# Altium schematic sheet Single Channel Isolated Gate Driver Test Board.SchDot
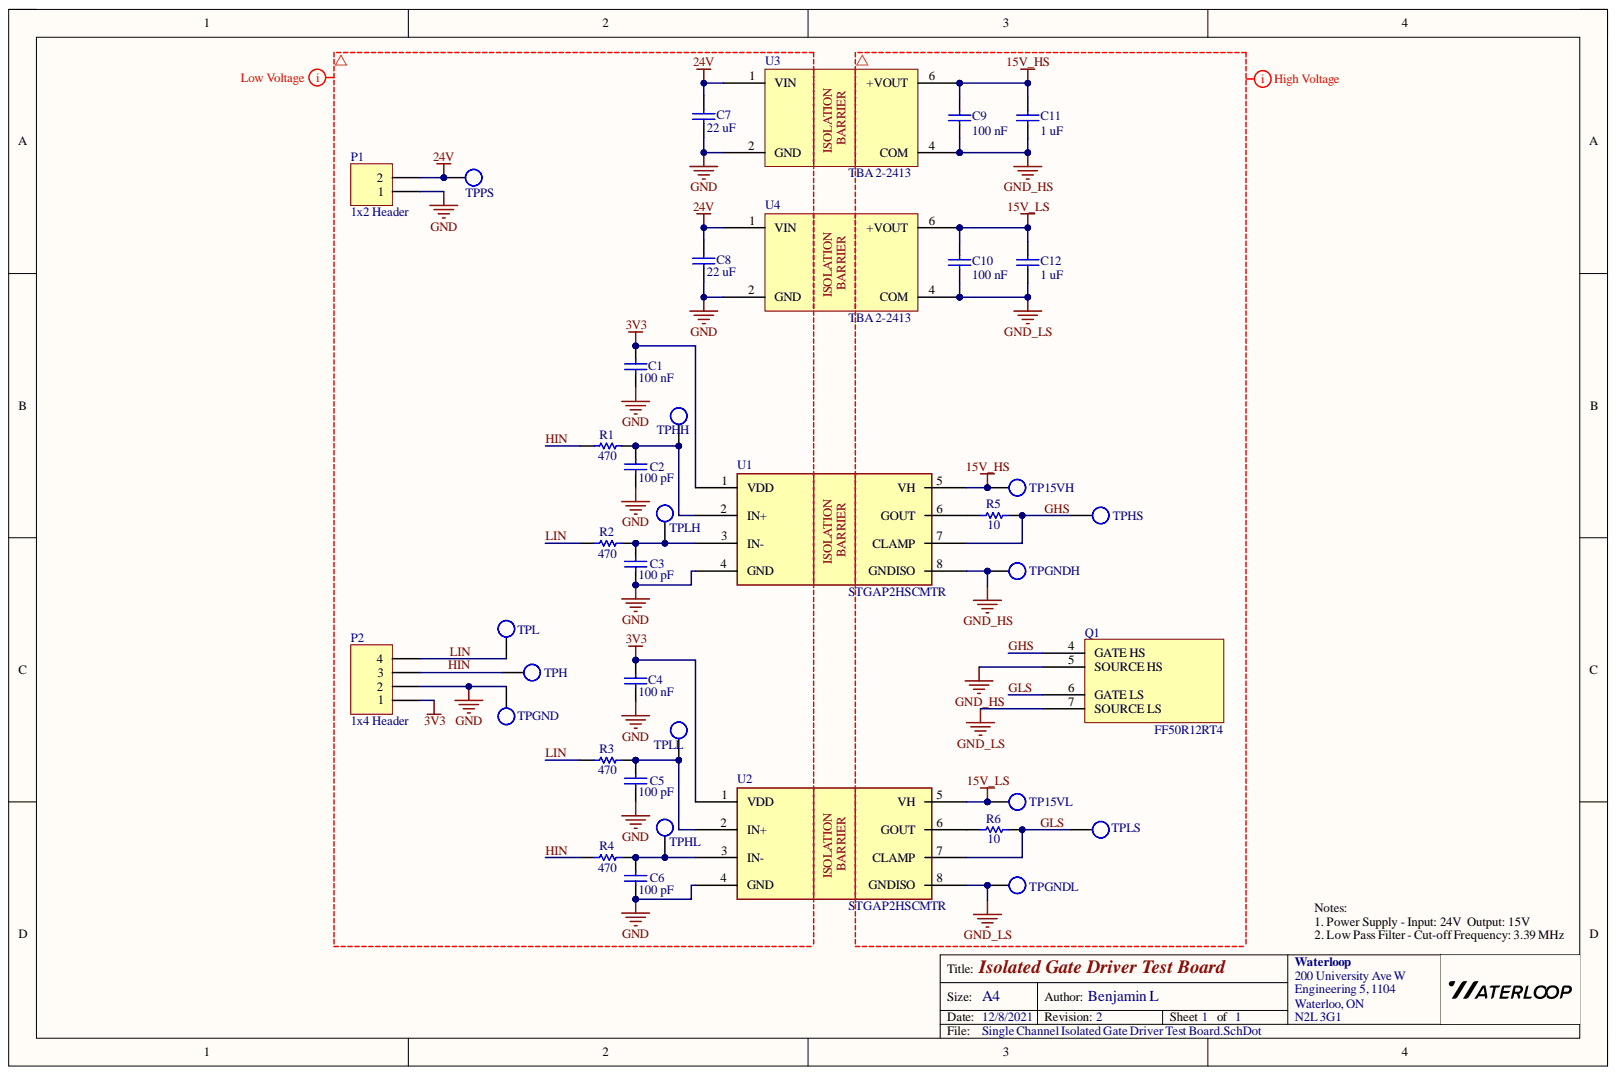
[diagram p0: Single Channel Isolated Gate Driver Test Board.SchDot]

## Parts
C1  value 100 nF  [0805 - Capacitor]  (hidden comment: Capacitor - Nonpolarized)
C2  value 100 pF  [0805 - Capacitor]  (hidden comment: Capacitor - Nonpolarized)
C3  value 100 pF  [0805 - Capacitor]  (hidden comment: Capacitor - Nonpolarized)
C4  value 100 nF  [0805 - Capacitor]  (hidden comment: Capacitor - Nonpolarized)
C5  value 100 pF  [0805 - Capacitor]  (hidden comment: Capacitor - Nonpolarized)
C6  value 100 pF  [0805 - Capacitor]  (hidden comment: Capacitor - Nonpolarized)
C7  value 22 uF  [1206 - Capacitor]  (hidden comment: Capacitor - Nonpolarized)
C8  value 22 uF  [1206 - Capacitor]  (hidden comment: Capacitor - Nonpolarized)
C9  value 100 nF  [0805 - Capacitor]  (hidden comment: Capacitor - Nonpolarized)
C10  value 100 nF  [0805 - Capacitor]  (hidden comment: Capacitor - Nonpolarized)
C11  value 1 uF  [0805 - Capacitor]  (hidden comment: Capacitor - Nonpolarized)
C12  value 1 uF  [0805 - Capacitor]  (hidden comment: Capacitor - Nonpolarized)
P1  1x2 Header  [B2B-XH]
P2  1x4 Header  [B4B-XH]
Q1  FF50R12RT4  [FF50R12RT4]
R1  value 470  [0603 - Resistor]  (hidden comment: Resistor)
R2  value 470  [0603 - Resistor]  (hidden comment: Resistor)
R3  value 470  [0603 - Resistor]  (hidden comment: Resistor)
R4  value 470  [0603 - Resistor]  (hidden comment: Resistor)
R5  value 10  [1206 - Resistor]  (hidden comment: Resistor)
R6  value 10  [1206 - Resistor]  (hidden comment: Resistor)
TP15VH  Test Point  [Test Point]  (value not drawn)  (no BOM)
TP15VL  Test Point  [Test Point]  (value not drawn)  (no BOM)
TPGND  Test Point  [Test Point]  (value not drawn)  (no BOM)
TPGNDH  Test Point  [Test Point]  (value not drawn)  (no BOM)
TPGNDL  Test Point  [Test Point]  (value not drawn)  (no BOM)
TPH  Test Point  [Test Point]  (value not drawn)  (no BOM)
TPHH  Test Point  [Test Point]  (value not drawn)  (no BOM)
TPHL  Test Point  [Test Point]  (value not drawn)  (no BOM)
TPHS  Test Point  [Test Point]  (value not drawn)  (no BOM)
TPL  Test Point  [Test Point]  (value not drawn)  (no BOM)
TPLH  Test Point  [Test Point]  (value not drawn)  (no BOM)
TPLL  Test Point  [Test Point]  (value not drawn)  (no BOM)
TPLS  Test Point  [Test Point]  (value not drawn)  (no BOM)
TPPS  Test Point  [Test Point]  (value not drawn)  (no BOM)
U1  STGAP2HSCMTR  [STGAP2HSCMTR]
U2  STGAP2HSCMTR  [STGAP2HSCMTR]
U3  TBA 2-2413  [TBA 2-2413]
U4  TBA 2-2413  [TBA 2-2413]

## Nets
3V3: C1.1 C4.1 P2.1 U1.1(VDD) U2.1(VDD)
15V_HS: C9.2 C11.2 TP15VH.1 U1.5(VH) U3.6(+VOUT)
15V_LS: C10.2 C12.2 TP15VL.1 U2.5(VH) U4.6(+VOUT)
24V: C7.2 C8.2 P1.2 TPPS.1 U3.1(VIN) U4.1(VIN)
GND: C1.2 C2.1 C3.1 C4.2 C5.1 C6.1 C7.1 C8.1 P1.1 P2.2 TPGND.1 U1.4(GND) U2.4(GND) U3.2(GND) U4.2(GND)
GND_HS: C9.1 C11.1 Q1.5(SOURCE_HS) TPGNDH.1 U1.8(GNDISO) U3.4(COM)
GND_LS: C10.1 C12.1 Q1.7(SOURCE_LS) TPGNDL.1 U2.8(GNDISO) U4.4(COM)
GHS: Q1.4(GATE_HS) R5.2 TPHS.1 U1.7(CLAMP)
GLS: Q1.6(GATE_LS) R6.2 TPLS.1 U2.7(CLAMP)
HIN: P2.3 R1.1 R4.1 TPH.1
LIN: P2.4 R2.1 R3.1 TPL.1
NetC2_2: C2.2 R1.2 TPHH.1 U1.2(IN+)
NetC3_2: C3.2 R2.2 TPLH.1 U1.3(IN-)
NetC5_2: C5.2 R3.2 TPLL.1 U2.2(IN+)
NetC6_2: C6.2 R4.2 TPHL.1 U2.3(IN-)
NetR5_1: R5.1 U1.6(GOUT)
NetR6_1: R6.1 U2.6(GOUT)

## Library data of the parts
C1 C4 C10 = Capacitor - Nonpolarized: Nonpolarized Capacitor; Manufacturer: YAGEO; Manufacturer Part Number: CC0805KRX7R9BB104; Rating: 50 V; Component Kind: Standard; Library Name: General.SchLib; Signal Integrity: Cap; Simulation: CAP; Supplier: DigiKey; Supplier Part Number: 311-1140-1-ND
C2 C3 C5 C6 = Capacitor - Nonpolarized: Nonpolarized Capacitor; Manufacturer: YAGEO; Manufacturer Part Number: CC0805JRNPO9BN101; Rating: 50 V; Component Kind: Standard; Library Name: General.SchLib; Signal Integrity: Cap; Simulation: CAP; Supplier: DigiKey; Supplier Part Number: 311-1111-1-ND
C7 = Capacitor - Nonpolarized: Nonpolarized Capacitor; Manufacturer: Samsung Elctro-Mechanics; Manufacturer Part Number: CL31A226KAHNNNE; Rating: 25 V; Component Kind: Standard; Library Name: General.SchLib; Signal Integrity: Cap; Simulation: CAP; Supplier: DigiKey; Supplier Part Number: 1276-3047-1-ND
C8 = Capacitor - Nonpolarized: Nonpolarized Capacitor; Manufacturer: Samsung Electro-Mechanics; Manufacturer Part Number: CL31A226KAHNNNE; Rating: 25 V; Component Kind: Standard; Library Name: General.SchLib; Signal Integrity: Cap; Simulation: CAP; Supplier: DigiKey; Supplier Part Number: 1276-3047-1-ND
C9 = Capacitor - Nonpolarized: Nonpolarized Capacitor; Manufacturer: YAGEO; Manufacturer Part Number: CC0805KRX7R9BB104; Rating: 50 V; Value: 100 nF; Component Kind: Standard; Library Name: General.SchLib; Signal Integrity: Cap; Simulation: CAP; Supplier: DigiKey; Supplier Part Number: 311-1140-1-ND
C11 C12 = Capacitor - Nonpolarized: Nonpolarized Capacitor; Manufacturer: YAGEO; Manufacturer Part Number: CC0805MKY5V9BB105; Rating: 50 V; Component Kind: Standard; Library Name: General.SchLib; Signal Integrity: Cap; Simulation: CAP; Supplier: DigiKey; Supplier Part Number: 311-3435-1-ND
P1 = 1x2 Header: 1x2 Pin Header; Component Kind: Standard; Library Name: GeneralConnectors.SchLib; Signal Integrity: Connector
P2 = 1x4 Header: 1x4 Pin Header; Component Kind: Standard; Library Name: GeneralConnectors.SchLib; Signal Integrity: Connector
Q1 = FF50R12RT4: IGBT-Module Gate Driver Header; Manufacturer: Infineon Technologies; Manufacturer Part Number: FF50R12RT4HOSA1; Component Kind: Standard; Library Name: MC.SchLib; Supplier: DigiKey; Supplier Part Number: FF50R12RT4HOSA1-ND
R1-R4 = Resistor: Manufacturer: YAGEO; Manufacturer Part Number: RC0603FR-07470RL; Rating: 0.1 W; Component Kind: Standard; Description: Resistor; Library Name: General.SchLib; Signal Integrity: Res; Simulation: RESISTOR; Supplier: DigiKey; Supplier Part Number: 311-470HRCT-ND
R5 R6 = Resistor: Manufacturer: Stackpole Electronics; Manufacturer Part Number: RMCF1206FT10R0; Rating: 0.25 W; Component Kind: Standard; Description: Resistor; Library Name: General.SchLib; Signal Integrity: Res; Simulation: RESISTOR; Supplier: DigiKey; Supplier Part Number: RMCF1206FT10R0CT-ND
U1 U2 = STGAP2HSCMTR: Isolated Gate Driver 4A Sink/Source; Manufacturer: STMicroelectronics; Component Kind: Standard; Library Name: MC.SchLib; Manufacturer Part Number: STGAP2HSCMTR; Supplier: DigiKey; Supplier Part Number: 497-STGAP2HSCMCT-ND
U3 U4 = TBA 2-2413: DC DC CONVERTER 15V 2W; Manufacturer: Traco Power; Component Kind: Standard; Library Name: MC.SchLib; Manufacturer Part Number: TBA 2-2413; Supplier: DigiKey; Supplier Part Number: 1951-3443-ND
TP15VH TP15VL TPGND TPGNDH TPGNDL TPH TPHH TPHL TPHS TPL TPLH TPLL TPLS TPPS = Test Point: Description: Test Point; Library Name: General.SchLib
